# Altium schematic sheet Holiday r3.SchDoc("Holiday r3")
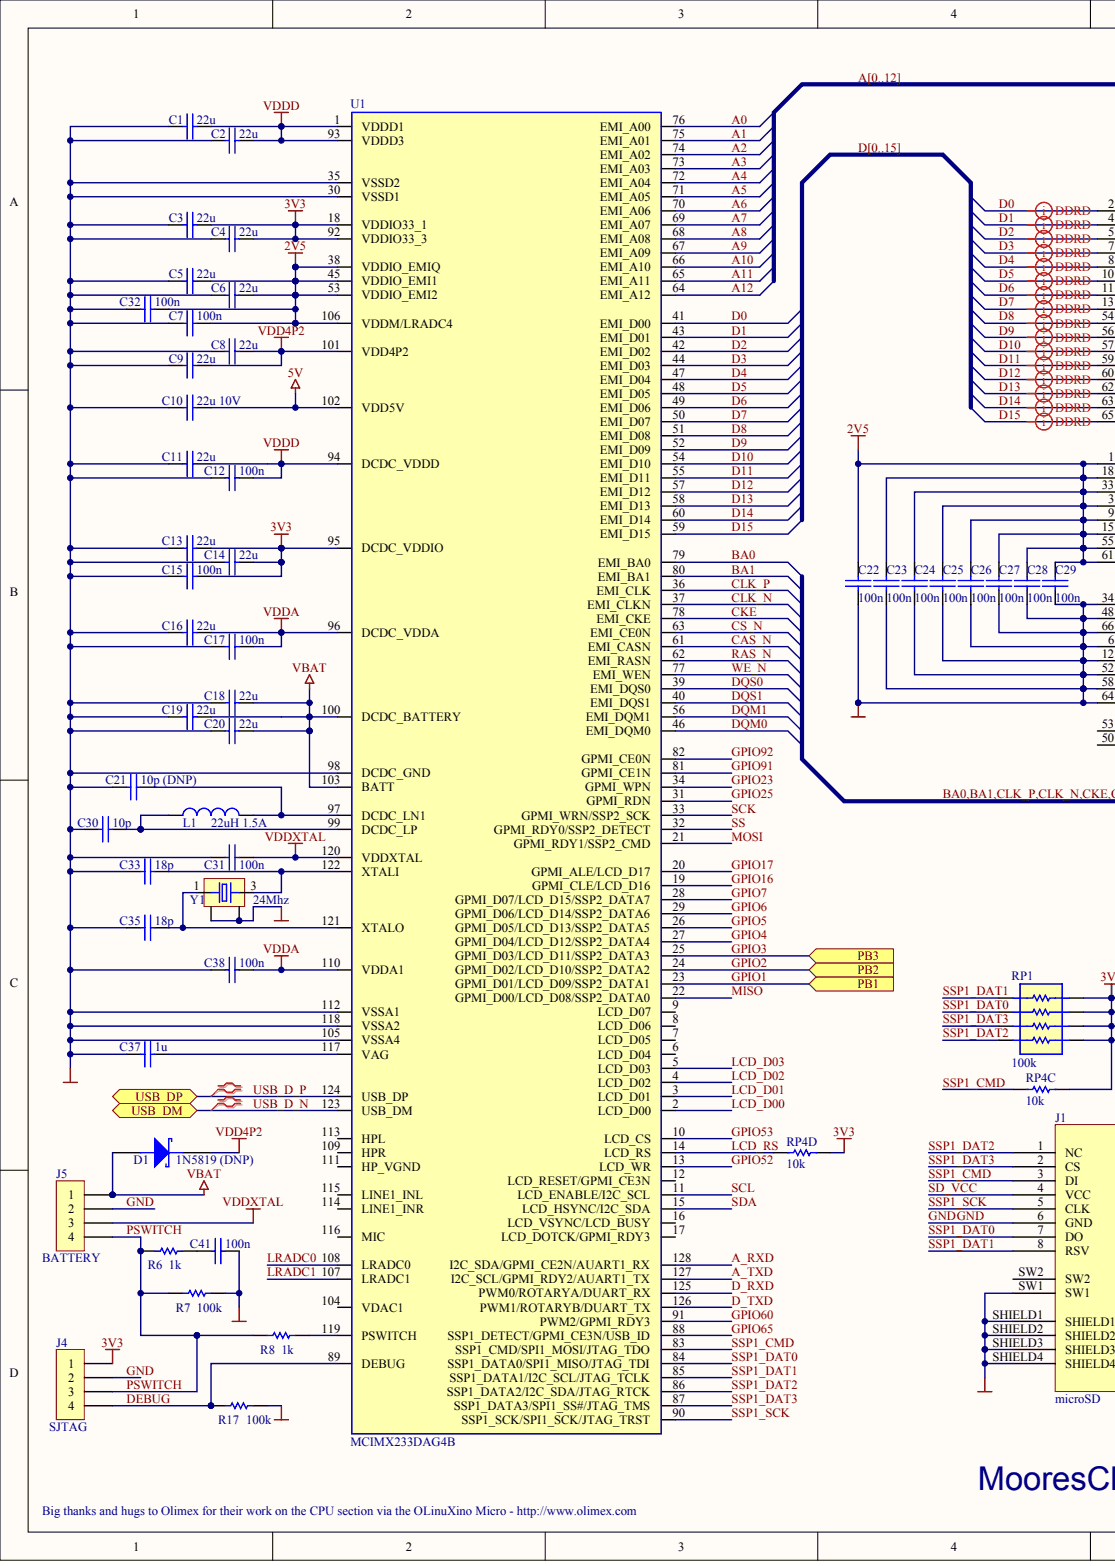
[diagram p0: Holiday r3.SchDoc, left]
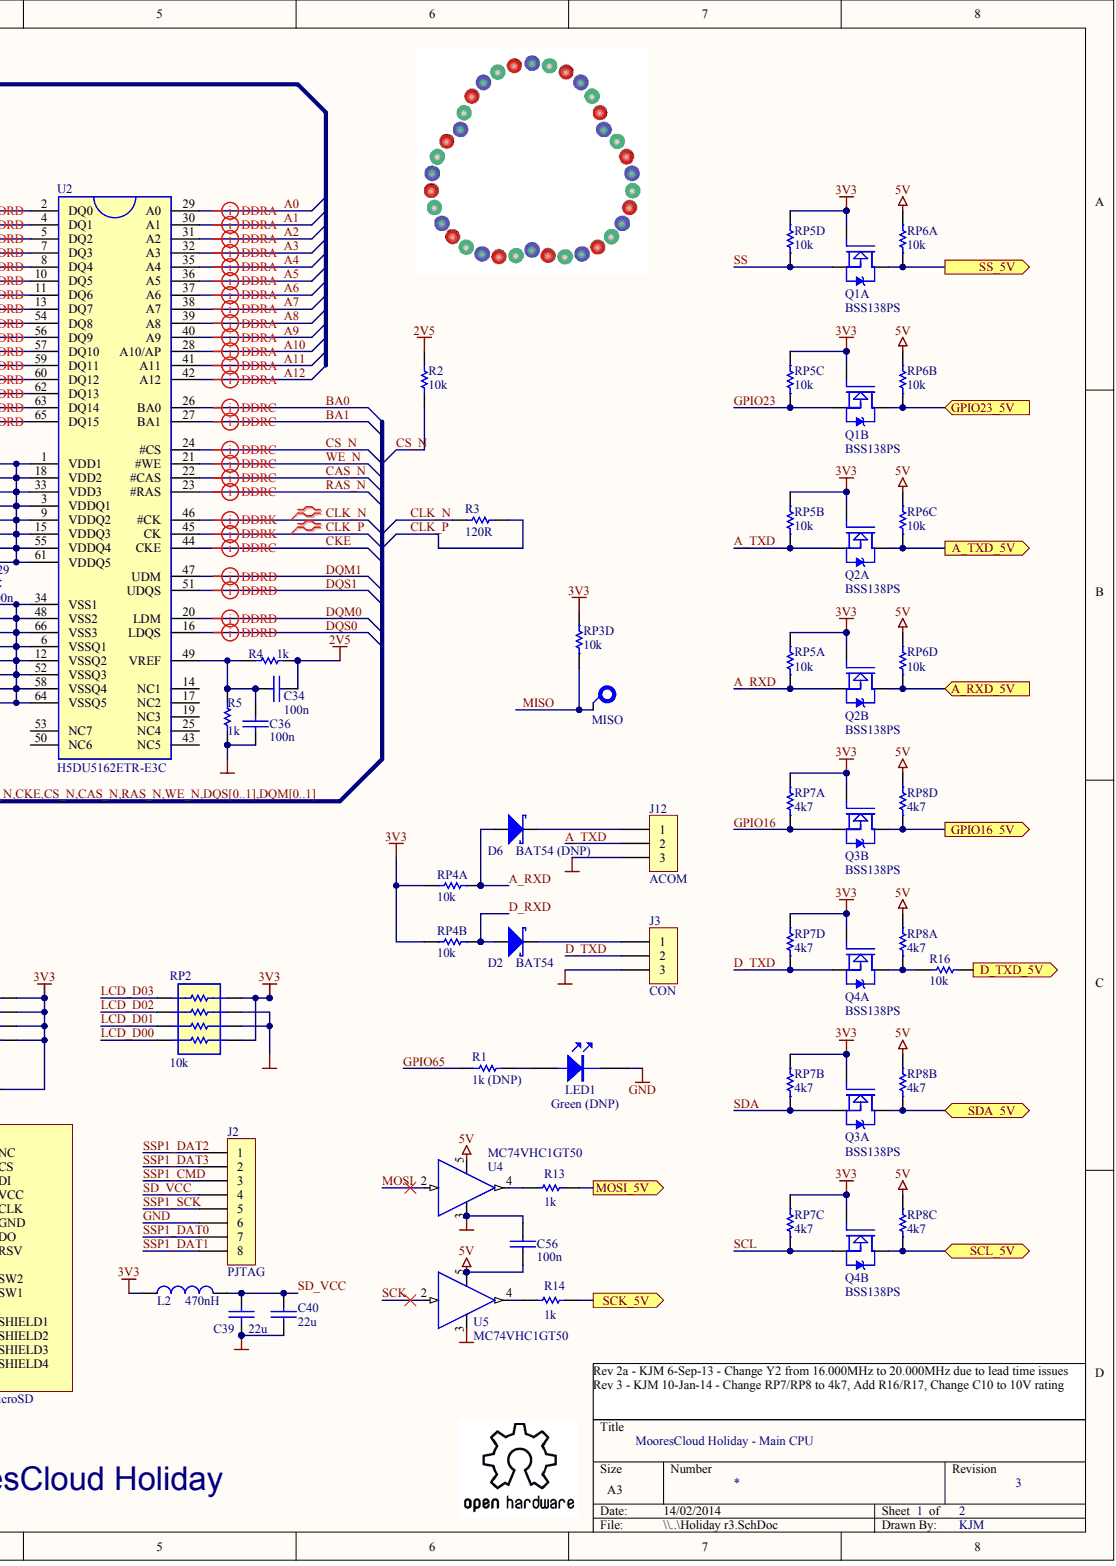
[diagram p1: Holiday r3.SchDoc, right]

## Parts
C1  22u
C2  22u
C3  22u
C4  22u
C5  22u
C6  22u
C7  100n
C8  22u
C9  22u
C10  22u 10V
C11  22u
C12  100n
C13  22u
C14  22u
C15  100n
C16  22u
C17  100n
C18  22u
C19  22u
C20  22u
C21  10p (DNP)
C22  100n
C23  100n
C24  100n
C25  100n
C26  100n
C27  100n
C28  100n
C29  100n
C30  10p
C31  100n
C32  100n
C33  18p
C34  100n
C35  18p
C36  100n
C37  1u
C38  100n
C39  22u
C40  22u
C41  100n
C56  100n
D1  1N5819 (DNP)
D2  BAT54
D6  BAT54 (DNP)  2.MfgNo: BAT54
J1  microSD
J2  PJTAG
J3  CON
J4  SJTAG
J5  BATTERY
J12  ACOM
L1  22uH 1.5A
L2  470nH
LED1  Green (DNP)
Q1  BSS138PS  (parts A,B)
Q2  BSS138PS  (parts A,B)
Q3  BSS138PS  (parts A,B)
Q4  BSS138PS  (parts A,B)
R1  1k (DNP)
R2  10k
R3  120R
R4  1k
R5  1k
R6  1k
R7  100k
R8  1k
R13  1k
R14  1k
R16  10k
R17  100k
RP1  100k
RP2  10k
RP3  10k  (part D)
RP4  10k  (parts A,B,C,D)
RP5  10k  (parts A,B,C,D)
RP6  10k  (parts A,B,C,D)
RP7  4k7  (parts A,B,C,D)
RP8  4k7  (parts A,B,C,D)
TP1  MISO
U1  MCIMX233DAG4B
U2  H5DU5162ETR-E3C
U4  MC74VHC1GT50
U5  MC74VHC1GT50
Y1  24Mhz

## Ports
A_RXD_5V, A_TXD_5V, D_TXD_5V, GPIO16_5V, GPIO23_5V, MOSI_5V, PB1, PB2, PB3, SCK_5V, SCL_5V, SDA_5V, SS_5V, USB_DM, USB_DP

## Nets
2V5: C5.1 C6.1 C7.1 C22.1 C23.1 C24.1 C25.1 C26.1 C27.1 C28.1 C29.1 C32.1 C34.1 R2.2 R4.2 U1.38(VDDIO_EMIQ) U1.45(VDDIO_EMI1) U1.53(VDDIO_EMI2) U1.106(VDDM/LRADC4) U2.1(VDD1) U2.3(VDDQ1) U2.9(VDDQ2) U2.15(VDDQ3) U2.18(VDD2) U2.33(VDD3) U2.55(VDDQ4) U2.61(VDDQ5)
3V3: C3.1 C4.1 C13.1 C14.1 C15.1 J4.1 L2.2 Q1.2 Q1.5 Q2.2 Q2.5 Q3.2 Q3.5 Q4.2 Q4.5 RP1.1.2 RP1.2.2 RP1.3.2 RP1.4.2 RP2.1.2 RP2.4.2 RP3.4.2 RP4.1.2 RP4.2.2 RP4.3.2 RP4.4.2 RP5.1.2 RP5.2.2 RP5.3.2 RP5.4.2 RP7.1.2 RP7.2.2 RP7.3.2 RP7.4.2 U1.18(VDDIO33_1) U1.92(VDDIO33_3) U1.95(DCDC_VDDIO)
5V: C10.1 C56.2 RP6.1.2 RP6.2.2 RP6.3.2 RP6.4.2 RP8.1.2 RP8.2.2 RP8.3.2 RP8.4.2 U1.102(VDD5V) U4.5 U5.5
VBAT: C18.1 C19.1 C20.1 D1.1 J5.1 U1.100(DCDC_BATTERY) U1.103(BATT)
VDD4P2: C8.1 C9.1 D1.2 U1.101(VDD4P2)
VDDA: C16.1 C17.1 C38.1 U1.96(DCDC_VDDA) U1.110(VDDA1)
VDDD: C1.1 C2.1 C11.1 C12.1 U1.1(VDDD1) U1.93(VDDD3) U1.94(DCDC_VDDD)
VDDXTAL: C31.1 J5.3 U1.120(VDDXTAL)
A0: U1.76(EMI_A00) U2.29(A0)  [also labelled A]
A1: U1.75(EMI_A01) U2.30(A1)  [also labelled A]
A2: U1.74(EMI_A02) U2.31(A2)  [also labelled A]
A3: U1.73(EMI_A03) U2.32(A3)  [also labelled A]
A4: U1.72(EMI_A04) U2.35(A4)  [also labelled A]
A5: U1.71(EMI_A05) U2.36(A5)  [also labelled A]
A6: U1.70(EMI_A06) U2.37(A6)  [also labelled A]
A7: U1.69(EMI_A07) U2.38(A7)  [also labelled A]
A8: U1.68(EMI_A08) U2.39(A8)  [also labelled A]
A9: U1.67(EMI_A09) U2.40(A9)  [also labelled A]
A10: U1.66(EMI_A10) U2.28(A10/AP)  [also labelled A]
A11: U1.65(EMI_A11) U2.41(A11)  [also labelled A]
A12: U1.64(EMI_A12) U2.42(A12)  [also labelled A]
A_RXD: D6.1 Q2.4 RP4.1.1 RP5.1.1 U1.128(I2C_SDA/GPMI_CE2N/AUART1_RX)
A_RXD_5V: Q2.3 RP6.4.1 ; port A_RXD_5V
A_TXD: J12.2 Q2.1 RP5.2.1 U1.127(I2C_SCL/GPMI_RDY2/AUART1_TX)
A_TXD_5V: Q2.6 RP6.3.1 ; port A_TXD_5V
BA0: U1.79(EMI_BA0) U2.26(BA0)
BA1: U1.80(EMI_BA1) U2.27(BA1)
CAS_N: U1.61(EMI_CASN) U2.22(#CAS)
CKE: U1.78(EMI_CKE) U2.44(CKE)
CLK_N: R3.1 U1.37(EMI_CLKN) U2.46(#CK)
CLK_P: R3.2 U1.36(EMI_CLK) U2.45(CK)
CS_N: R2.1 U1.63(EMI_CE0N) U2.24(#CS)
D0: U1.41(EMI_D00) U2.2(DQ0)  [also labelled D]
D1: U1.43(EMI_D01) U2.4(DQ1)  [also labelled D]
D2: U1.42(EMI_D02) U2.5(DQ2)  [also labelled D]
D3: U1.44(EMI_D03) U2.7(DQ3)  [also labelled D]
D4: U1.47(EMI_D04) U2.8(DQ4)  [also labelled D]
D5: U1.48(EMI_D05) U2.10(DQ5)  [also labelled D]
D6: U1.49(EMI_D06) U2.11(DQ6)  [also labelled D]
D7: U1.50(EMI_D07) U2.13(DQ7)  [also labelled D]
D8: U1.51(EMI_D08) U2.54(DQ8)  [also labelled D]
D9: U1.52(EMI_D09) U2.56(DQ9)  [also labelled D]
D10: U1.54(EMI_D10) U2.57(DQ10)  [also labelled D]
D11: U1.55(EMI_D11) U2.59(DQ11)  [also labelled D]
D12: U1.57(EMI_D12) U2.60(DQ12)  [also labelled D]
D13: U1.58(EMI_D13) U2.62(DQ13)  [also labelled D]
D14: U1.60(EMI_D14) U2.63(DQ14)  [also labelled D]
D15: U1.59(EMI_D15) U2.65(DQ15)  [also labelled D]
D_RXD: D2.1 RP4.2.1 U1.125(PWM0/ROTARYA/DUART_RX)
D_TXD: J3.2 Q4.1 RP7.4.1 U1.126(PWM1/ROTARYB/DUART_TX)
D_TXD_5V: R16.1 ; port D_TXD_5V
DEBUG: J4.4 R17.2 U1.89(DEBUG)
DQM0: U1.46(EMI_DQM0) U2.20(LDM)
DQM1: U1.56(EMI_DQM1) U2.47(UDM)
DQS0: U1.39(EMI_DQS0) U2.16(LDQS)
DQS1: U1.40(EMI_DQS1) U2.51(UDQS)
GND: C1.2 C2.2 C3.2 C4.2 C5.2 C6.2 C7.2 C8.2 C9.2 C10.2 C11.2 C12.2 C13.2 C14.2 C15.2 C16.2 C17.2 C18.2 C19.2 C20.2 C21.2 C22.2 C23.2 C24.2 C25.2 C26.2 C27.2 C28.2 C29.2 C30.2 C31.2 C32.2 C33.2 C35.2 C36.2 C37.2 C38.2 C39.2 C40.2 C41.2 C56.1 J1.6(GND) J1.SHIELD1 J1.SHIELD2 J1.SHIELD3 J1.SHIELD4 J1.SW1 J2.6 J3.3 J4.2 J5.2 J12.3 LED1.K R5.2 R7.1 R17.1 RP2.2.2 RP2.3.2 U1.30(VSSD1) U1.35(VSSD2) U1.98(DCDC_GND) U1.105(VSSA4) U1.112(VSSA1) U1.118(VSSA2) U2.6(VSSQ1) U2.12(VSSQ2) U2.34(VSS1) U2.48(VSS2) U2.52(VSSQ3) U2.58(VSSQ4) U2.64(VSSQ5) U2.66(VSS3) U4.3 U5.3 Y1.2 Y1.4
GPIO1: U1.23(GPMI_D01/LCD_D09/SSP2_DATA1) ; port PB1
GPIO2: U1.24(GPMI_D02/LCD_D10/SSP2_DATA2) ; port PB2
GPIO3: U1.25(GPMI_D03/LCD_D11/SSP2_DATA3) ; port PB3
GPIO4: U1.27(GPMI_D04/LCD_D12/SSP2_DATA4)
GPIO5: U1.26(GPMI_D05/LCD_D13/SSP2_DATA5)
GPIO6: U1.29(GPMI_D06/LCD_D14/SSP2_DATA6)
GPIO7: U1.28(GPMI_D07/LCD_D15/SSP2_DATA7)
GPIO16: Q3.4 RP7.1.1 U1.19(GPMI_CLE/LCD_D16)
GPIO16_5V: Q3.3 RP8.4.1 ; port GPIO16_5V
GPIO17: U1.20(GPMI_ALE/LCD_D17)
GPIO23: Q1.4 RP5.3.1 U1.34(GPMI_WPN)
GPIO23_5V: Q1.3 RP6.2.1 ; port GPIO23_5V
GPIO25: U1.31(GPMI_RDN)
GPIO52: U1.13(LCD_WR)
GPIO53: U1.10(LCD_CS)
GPIO60: U1.91(PWM2/GPMI_RDY3)
GPIO65: R1.2 U1.88(SSP1_DETECT/GPMI_CE3N/USB_ID)
GPIO91: U1.81(GPMI_CE1N)
GPIO92: U1.82(GPMI_CE0N)
LCD_D00: RP2.4.1 U1.2(LCD_D00)
LCD_D01: RP2.3.1 U1.3(LCD_D01)
LCD_D02: RP2.2.1 U1.4(LCD_D02)
LCD_D03: RP2.1.1 U1.5(LCD_D03)
LCD_RS: RP4.4.1 U1.14(LCD_RS)
LRADC0: U1.108(LRADC0)
LRADC1: U1.107(LRADC1)
MISO: RP3.4.1 TP1.1 U1.22(GPMI_D00/LCD_D08/SSP2_DATA0)
MOSI: U1.21(GPMI_RDY1/SSP2_CMD) U4.2
MOSI_5V: R13.1 ; port MOSI_5V
PSWITCH: J4.3 J5.4 R6.2 R7.2 R8.2
RAS_N: U1.62(EMI_RASN) U2.23(#RAS)
SCK: U1.33(GPMI_WRN/SSP2_SCK) U5.2
SCK_5V: R14.1 ; port SCK_5V
SCL: Q4.4 RP7.3.1 U1.11(LCD_ENABLE/I2C_SCL)
SCL_5V: Q4.3 RP8.3.1 ; port SCL_5V
SD_VCC: C39.1 C40.1 J1.4(VCC) J2.4 L2.1
SDA: Q3.1 RP7.2.1 U1.15(LCD_HSYNC/I2C_SDA)
SDA_5V: Q3.6 RP8.2.1 ; port SDA_5V
SS: Q1.1 RP5.4.1 U1.32(GPMI_RDY0/SSP2_DETECT)
SS_5V: Q1.6 RP6.1.1 ; port SS_5V
SSP1_CMD: J1.3(DI) J2.3 RP4.3.1 U1.83(SSP1_CMD/SPI1_MOSI/JTAG_TDO)
SSP1_DAT0: J1.7(DO) J2.7 RP1.2.1 U1.84(SSP1_DATA0/SPI1_MISO/JTAG_TDI)
SSP1_DAT1: J1.8(RSV) J2.8 RP1.1.1 U1.85(SSP1_DATA1/I2C_SCL/JTAG_TCLK)
SSP1_DAT2: J1.1(NC) J2.1 RP1.4.1 U1.86(SSP1_DATA2/I2C_SDA/JTAG_RTCK)
SSP1_DAT3: J1.2(CS) J2.2 RP1.3.1 U1.87(SSP1_DATA3/SPI1_SS#/JTAG_TMS)
SSP1_SCK: J1.5(CLK) J2.5 U1.90(SSP1_SCK/SPI1_SCK/JTAG_TRST)
USB_D_N: U1.123(USB_DM) ; port USB_DM
USB_D_P: U1.124(USB_DP) ; port USB_DP
WE_N: U1.77(EMI_WEN) U2.21(#WE)
NetC21_1: C21.1 L1.1 U1.97(DCDC_LN1)
NetC30_1: C30.1 L1.2 U1.99(DCDC_LP)
NetC33_1: C33.1 U1.122(XTALI) Y1.3
NetC34_2: C34.2 C36.1 R4.1 R5.1 U2.49(VREF)
NetC35_1: C35.1 U1.121(XTALO) Y1.1
NetC37_1: C37.1 U1.117(VAG)
NetC41_1: C41.1 R6.1
NetD2_2: D2.2 J3.1
NetD6_2: D6.2 J12.1
NetLED1_A: LED1.A R1.1
NetQ4_6: Q4.6 R16.2 RP8.1.1
NetR8_1: R8.1 U1.119(PSWITCH)
NetR13_2: R13.2 U4.4
NetR14_2: R14.2 U5.4
BA0,BA1,CLK_P,CLK_N,CKE,CS_N,CAS_N,RAS_N,WE_N,DQS0:  [also labelled BA0,BA1,CLK_P,CLK_N,CKE,CS_N,CAS_N,RAS_N,WE_N,DQS]
BA0,BA1,CLK_P,CLK_N,CKE,CS_N,CAS_N,RAS_N,WE_N,DQS1:  [also labelled BA0,BA1,CLK_P,CLK_N,CKE,CS_N,CAS_N,RAS_N,WE_N,DQS]

Not connected: J1 SW2; U1 6(LCD_D04) 7(LCD_D05) 8(LCD_D06) 9(LCD_D07) 12(LCD_RESET/GPMI_CE3N) 16(LCD_VSYNC/LCD_BUSY) 17(LCD_DOTCK/GPMI_RDY3) 104(VDAC1) 109(HPR) 111(HP_VGND) 113(HPL) 114(LINE1_INR) 115(LINE1_INL) 116(MIC); U2 14(NC1) 17(NC2) 19(NC3) 25(NC4) 43(NC5) 50(NC6) 53(NC7)

## Library data of the parts
C1-C6 C8 C9 C11 C13 C14 C16 C18-C20 C39 C40 = (no symbol name): 1.Manufacturer: TDK; 2.MfgNo: C1608X5R0J226M080AC; 4.CatNo: 445-8028-1-ND; 9.UnitCost: 0.0816; 3.Supplier: Digikey
C7 C12 C15 C17 C22-C29 C31 C32 C34 C36 C38 C41 C56 = (no symbol name): 1.Manufacturer: Yageo; 4.CatNo: 311-1343-2-ND; 2.MfgNo: CC0603ZRY5V9BB104; 9.UnitCost: 0.0034; 3.Supplier: Digikey
C10 = (no symbol name): 1.Manufacturer: TDK; 2.MfgNo: C1608X5R1A226M080AC; 4.CatNo: 445-9077-2-ND; 9.UnitCost: 0.10500; 3.Supplier: Digikey
C21 C30 = (no symbol name): 1.Manufacturer: Murata; 4.CatNo: 490-1403-1-ND; 2.MfgNo: GRM1885C1H100JA01D; 9.UnitCost: 0.008; 3.Supplier: Digikey
C33 C35 = (no symbol name): 1.Manufacturer: Murata; 2.MfgNo: GRM1885C1H180JA01D; 4.CatNo: 490-1409-2-ND; 9.UnitCost: 0.008; 3.Supplier: Digikey
C37 = (no symbol name): 1.Manufacturer: Yageo; 4.CatNo: 311-1372-2-ND; 2.MfgNo: CC0603ZRY5V7BB105; 9.UnitCost: 0.0083; 3.Supplier: Digikey
D1 = (no symbol name): 1.Manufacturer: Diodes Inc; 2.MfgNo: 1N5819HW-7-F; 4.CatNo: 1N5819HW-FDITR-ND; 9.UnitCost: 0.08; 3.Supplier: Digikey
D2 D6 = (no symbol name): 1.Manufacturer: Fairchild; 4.CatNo: BAT54FSTR-ND; 9.UnitCost: 0.027; 3.Supplier: Digikey
  D2: 2.MfgNo: BAT54
J1 = (no symbol name): 1.Manufacturer: Molex; 2.MfgNo: 473093751; 4.CatNo: WM3974TR-ND; 9.UnitCost: 0.90; 3.Supplier: Digikey
J2-J5 J12 = (no symbol name): 1.Manufacturer: DNP; 9.UnitCost: 0
L1 = (no symbol name): 1.Manufacturer: Taiyo Yuden; 2.MfgNo: NR6045T220M; 4.CatNo: 587-2082-1-ND; 9.UnitCost: 0.12; 3.Supplier: Digikey
L2 = (no symbol name): 1.Manufacturer: Abracon; 2.MfgNo: AISC-0805-R47J-T; 4.CatNo: 535-10509-1-ND; 9.UnitCost: 0.10; 3.Supplier: Digikey
LED1 = (no symbol name): 1.Manufacturer: Kingbright; 4.CatNo: 754-1131-1-ND; 9.UnitCost: 0.0611; 2.MfgNo: APT2012SGC; 3.Supplier: Digikey
Q1-Q4 = (no symbol name): 1.Manufacturer: NXP; 4.CatNo: 568-8393-2-ND; 2.MfgNo: BSS138PS,115; 9.UnitCost: 0.07; 3.Supplier: Digikey
R1 R4-R6 R8 R13 R14 = (no symbol name): 1.Manufacturer: Yageo; 4.CatNo: 311-1.00KHRTR-ND; 2.MfgNo: RC0603FR-071KL; 9.UnitCost: 0.0017; 3.Supplier: Digikey
R2 = (no symbol name): 1.Manufacturer: Yageo; 9.UnitCost: 0.0017; 4.CatNo: 311-10.0KHRTR-ND; 2.MfgNo: RC0603FR-0710KL; 3.Supplier: Digikey
R3 = (no symbol name): 1.Manufacturer: Yageo; 4.CatNo: 311-120HRTR-ND; 2.MfgNo: RC0603FR-07120RL; 9.UnitCost: 0.0017; 3.Supplier: Digikey
R7 R17 = (no symbol name): 1.Manufacturer: Yageo; 4.CatNo: 311-100KHRTR-ND; 2.MfgNo: RC0603FR-07100KL; 9.UnitCost: 0.0017; 3.Supplier: Digikey
R16 = (no symbol name): 1.Manufacturer: Yageo; 4.CatNo: 311-10.0KHRTR-ND; 2.MfgNo: RC0603FR-0710KL; 9.UnitCost: 0.0017; 3.Supplier: Digikey
RP1 = (no symbol name): 1.Manufacturer: Vishay Dale; 2.MfgNo: CRA06S083100KJTA; 4.CatNo: CRA6S8100KTR-ND; 9.UnitCost: 0.009; 3.Supplier: Digikey
RP2-RP6 = (no symbol name): 1.Manufacturer: Vishay Dale; 2.MfgNo: CRA06S08310K0JTA; 4.CatNo: CRA6S810KTR-ND; 9.UnitCost: 0.009; 3.Supplier: Digikey
RP7 RP8 = (no symbol name): 1.Manufacturer: Vishay Dale; 2.MfgNo: CRA06S0834K70JTA; 4.CatNo: CRA6S84.7KTR-ND; 9.UnitCost: 0.009; 3.Supplier: Digikey
U1 = (no symbol name): 1.Manufacturer: Freescale; 2.MfgNo: MCIMX233DAG4C; 4.CatNo: MCIMX233DAG4C-ND; 9.UnitCost: 4.880; 3.Supplier: Digikey
U2 = (no symbol name): 1.Manufacturer: Hynix; 9.UnitCost: 2.240; 2.MfgNo: H5DU5162ETR-E3C
U4 U5 = (no symbol name): 1.Manufacturer: On Semi; 2.MfgNo: M74VHC1GT50DTT1G; 4.CatNo: M74VHC1GT50DTT1GOSTR-ND; 9.UnitCost: 0.07; 3.Supplier: Digikey
Y1 = (no symbol name): 1.Manufacturer: Abracon; 2.MfgNo: ABMM2-24.000MHZ-E2-T; 4.CatNo: 535-9638-2-ND; 9.UnitCost: 0.48; 3.Supplier: Digikey
TP1 = (no symbol name): 3.Supplier: *
